# Altium schematic sheet 原理图.SchDoc
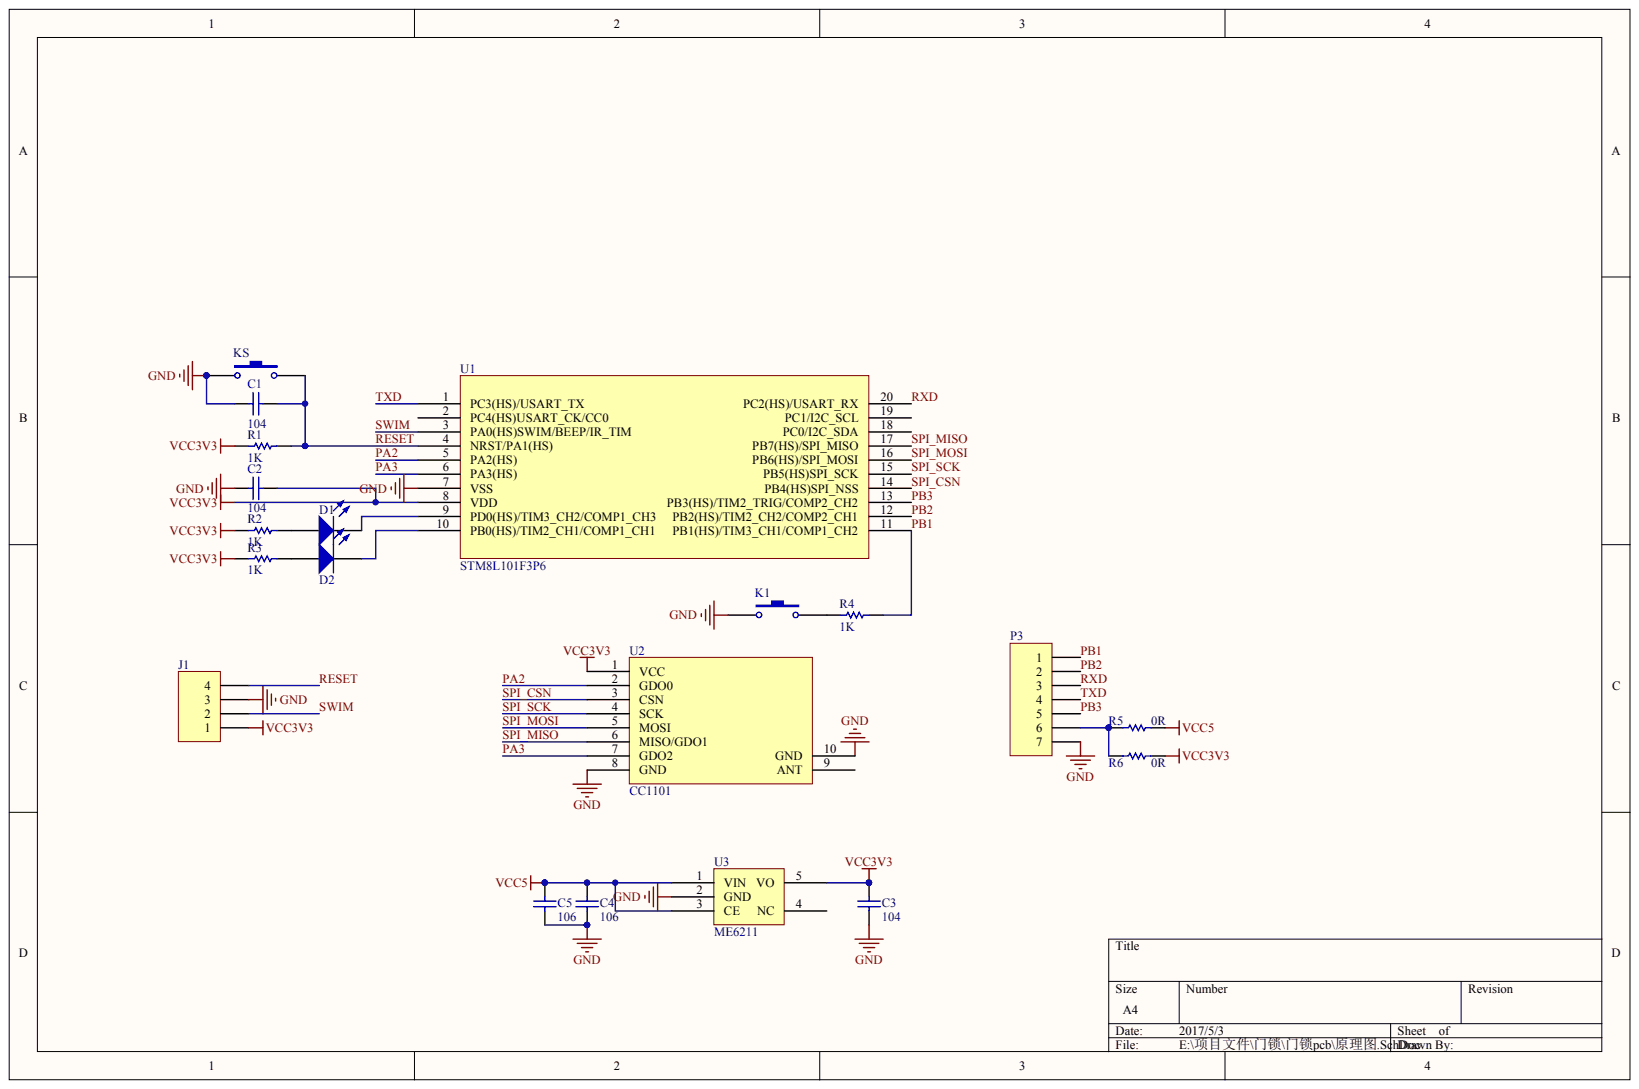
[diagram p0: 原理图.SchDoc]

## Parts
C1  value 104  (hidden comment: Cap)
C2  value 104  (hidden comment: Cap)
C3  value 104  (hidden comment: Cap)
C4  value 106  (hidden comment: Cap)
C5  value 106  (hidden comment: Cap)
D1  LED0  (value not drawn)
D2  LED0  (value not drawn)
J1  Header 4  (value not drawn)
K1  SW-PB  (value not drawn)
KS  SW-PB  (value not drawn)
P3  Header 7  (value not drawn)
R1  value 1K  (hidden comment: Res1)
R2  value 1K  (hidden comment: Res1)
R3  value 1K  (hidden comment: Res1)
R4  value 1K  (hidden comment: Res1)
R5  value 0R  (hidden comment: Res1)
R6  value 0R  (hidden comment: Res1)
U1  STM8L101F3P6
U2  CC1101
U3  ME6211

## Nets
GND: C1.1 C2.1 C3.1 C4.1 C5.1 J1.3 K1.1 KS.1 P3.7 U1.7(VSS) U2.8(GND) U2.10(GND) U3.2(GND)
VCC3V3: C2.2 C3.2 J1.1 R1.1 R2.1 R3.1 R6.2 U1.8(VDD) U2.1(VCC) U3.5(VO)
VCC5: C4.2 C5.2 R5.2 U3.1(VIN) U3.3(CE)
PA2: U1.5(PA2(HS)) U2.2(GDO0)
PA3: U1.6(PA3(HS)) U2.7(GDO2)
PB1: P3.1 R4.2 U1.11(PB1(HS)/TIM3_CH1/COMP1_CH2)
PB2: P3.2 U1.12(PB2(HS)/TIM2_CH2/COMP2_CH1)
PB3: P3.5 U1.13(PB3(HS)/TIM2_TRIG/COMP2_CH2)
RESET: C1.2 J1.4 KS.2 R1.2 U1.4(NRST/PA1(HS))
RXD: P3.3 U1.20(PC2(HS)/USART_RX)
SPI_CSN: U1.14(PB4(HS)SPI_NSS) U2.3(CSN)
SPI_MISO: U1.17(PB7(HS)/SPI_MISO) U2.6(MISO/GDO1)
SPI_MOSI: U1.16(PB6(HS)/SPI_MOSI) U2.5(MOSI)
SPI_SCK: U1.15(PB5(HS)SPI_SCK) U2.4(SCK)
SWIM: J1.2 U1.3(PA0(HS)SWIM/BEEP/IR_TIM)
TXD: P3.4 U1.1(PC3(HS)/USART_TX)
NetD1_1: D1.1 R2.2
NetD1_2: D1.2 U1.9(PD0(HS)/TIM3_CH2/COMP1_CH3)
NetD2_1: D2.1 R3.2
NetD2_2: D2.2 U1.10(PB0(HS)/TIM2_CH1/COMP1_CH1)
NetK1_2: K1.2 R4.1
NetP3_6: P3.6 R5.1 R6.1

Not connected: U1 2(PC4(HS)USART_CK/CC0) 18(PC0/I2C_SDA) 19(PC1/I2C_SCL); U2 9(ANT); U3 4(NC)

## Library data of the parts
C1-C5 D1 D2 J1 K1 KS P3 R1-R6 U1-U3 = (no symbol name)
  C1-C5: Published: 8-Jun-2000; LatestRevisionDate: 17-Jul-2002; LatestRevisionNote: Re-released for DXP Platform.; PackageReference: RAD-0.3; PackageDescription: Radial Cap, Thru-Hole; 2 Leads; 0.3 in Pin Spacing
  D1 D2: Published: 8-Jun-2000; LatestRevisionDate: 08-Jul-2005; LatestRevisionNote: Mech Layer 1 removed.; PackageReference: LED-0; PackageDescription: LED; 2 Leads
  J1 P3: LatestRevisionDate: 17-Jul-2002; LatestRevisionNote: Re-released for DXP Platform.
  K1 KS: Published: 24-Mar-1999; LatestRevisionDate: 17-Jul-2002; LatestRevisionNote: Re-released for DXP Platform.; PackageReference: SPST-2; PackageDescription: Switch; 2 Leads
  R1-R6: Published: 8-Jun-2000; LatestRevisionDate: 17-Jul-2002; LatestRevisionNote: Re-released for DXP Platform.; PackageReference: AXIAL-0.3; PackageDescription: Axial Device, Thru-Hole; 2 Leads; 0.3 in Pin Spacing
  C1-C5 D1 D2 J1 K1 KS P3 R1-R6: Publisher: Altium Limited
